# Altium schematic sheet ARDUINO_MINI_PRO.SchDoc
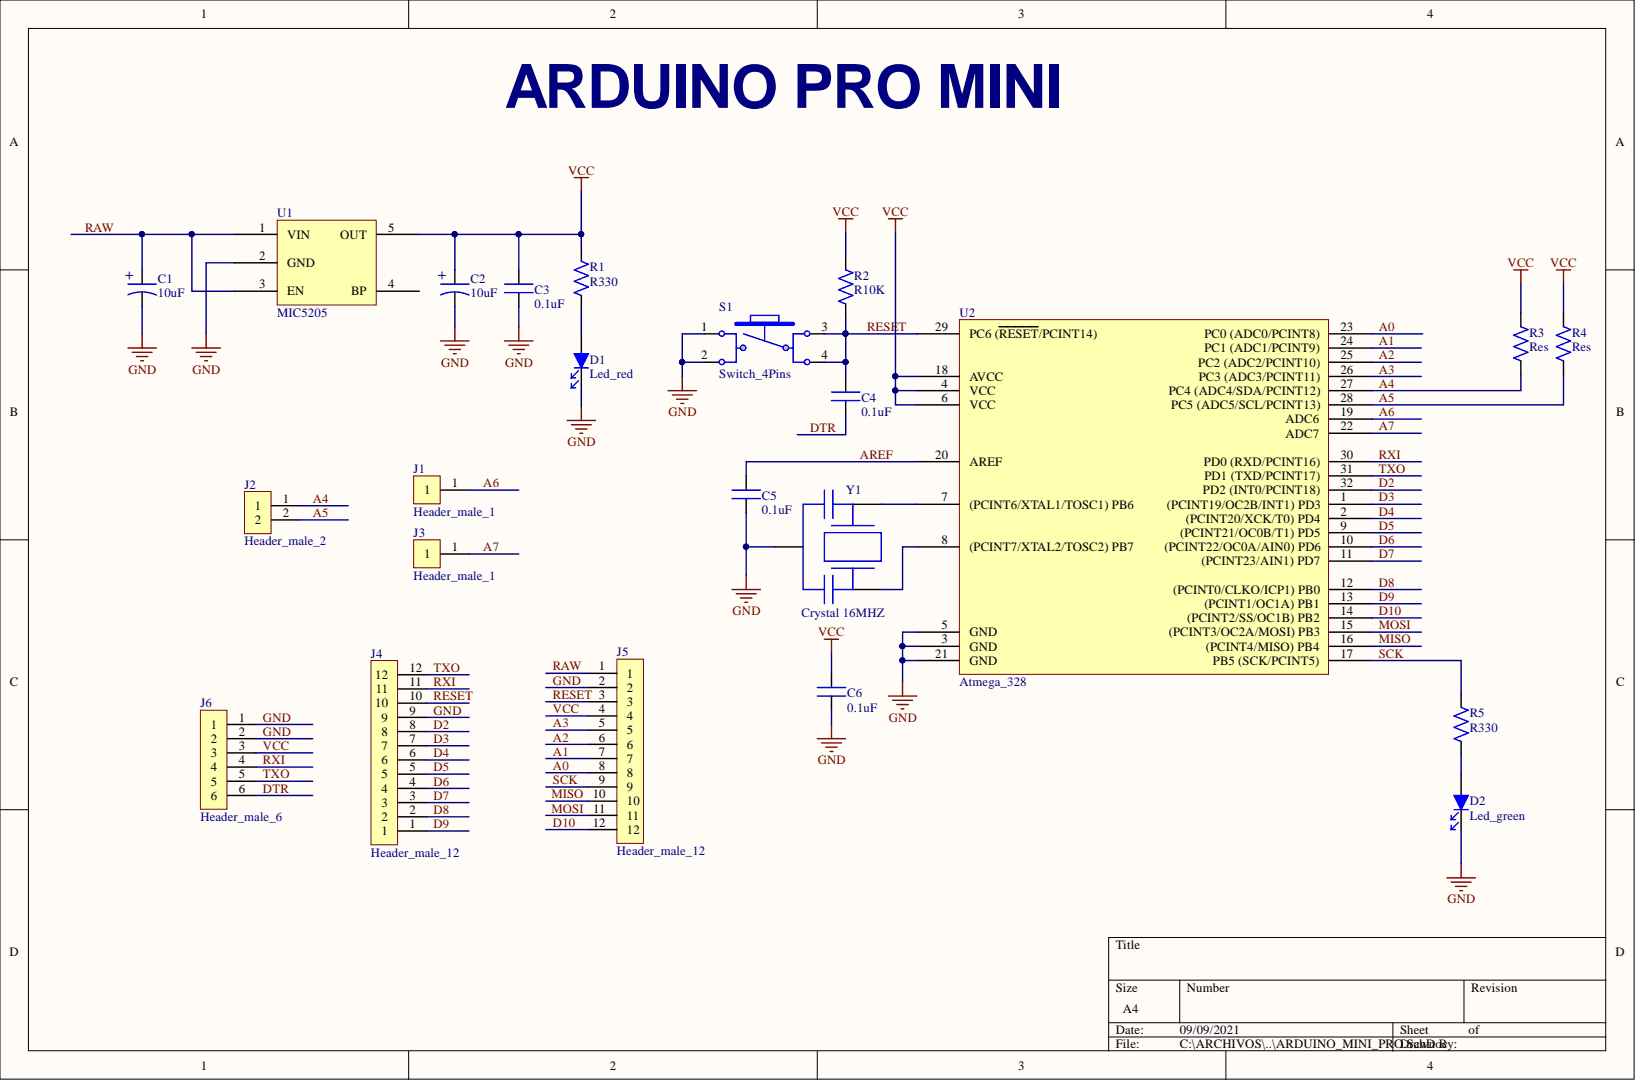
[diagram p0: ARDUINO_MINI_PRO.SchDoc]

## Parts
C1  10uF  [Cap3216]
C2  10uF  [Cap3216]
C3  0.1uF  [Cap_0402]
C4  0.1uF  [Cap_0402]
C5  0.1uF  [Cap_0402]
C6  0.1uF  [Cap_0402]
D1  Led_red  [Led_0603]
D2  Led_green  [Led_0603]
J1  Header_male_1  [Header_male_1]
J2  Header_male_2  [Header_male_2]
J3  Header_male_1  [Header_male_1]
J4  Header_male_12  [Header_male_12]
J5  Header_male_12  [Header_male_12]
J6  Header_male_6  [Header_male_6]
R1  R330  [Res_0402]
R2  R10K  [Res_0402]
R3  Res  [Res_0402_without3dmodel]
R4  Res  [Res_0402_without3dmodel]
R5  R330  [Res_0402]
S1  Switch_4Pins  [SWITCH_SMD_4_PINS]
U1  MIC5205  [SOT23_5]
U2  Atmega_328  [tqfp32_8]
Y1  Crystal 16MHZ  [CSTCE16M0V53-R0]

## Nets
A0: J5.8 U2.23(PC0_(ADC0/PCINT8))
A1: J5.7 U2.24(PC1_(ADC1/PCINT9))
A2: J5.6 U2.25(PC2_(ADC2/PCINT10))
A3: J5.5 U2.26(PC3_(ADC3/PCINT11))
A4: J2.1 R3.1 U2.27(PC4_(ADC4/SDA/PCINT12))
A5: J2.2 R4.1 U2.28(PC5_(ADC5/SCL/PCINT13))
A6: J1.1 U2.19(ADC6)
A7: J3.1 U2.22(ADC7)
AREF: C5.1 U2.20(AREF)
D2: J4.8 U2.32(PD2_(INT0/PCINT18))
D3: J4.7 U2.1((PCINT19/OC2B/INT1)_PD3)
D4: J4.6 U2.2((PCINT20/XCK/T0)_PD4)
D5: J4.5 U2.9((PCINT21/OC0B/T1)_PD5)
D6: J4.4 U2.10((PCINT22/OC0A/AIN0)_PD6)
D7: J4.3 U2.11((PCINT23/AIN1)_PD7)
D8: J4.2 U2.12((PCINT0/CLKO/ICP1)_PB0)
D9: J4.1 U2.13((PCINT1/OC1A)_PB1)
D10: J5.12 U2.14((PCINT2/SS/OC1B)_PB2)
DTR: C4.2 J6.6
GND: C1.2 C2.2 C3.2 C5.2 C6.2 D1.1 D2.1 J4.9 J5.2 J6.1 J6.2 S1.1 S1.2 U1.2(GND) U2.3(GND) U2.5(GND) U2.21(GND) Y1.2
MISO: J5.10 U2.16((PCINT4/MISO)_PB4)
MOSI: J5.11 U2.15((PCINT3/OC2A/MOSI)_PB3)
RAW: C1.1 J5.1 U1.1(VIN) U1.3(EN)
RESET: C4.1 J4.10 J5.3 R2.1 S1.3 S1.4 U2.29(PC6_(RESET/PCINT14))
RXI: J4.11 J6.4 U2.30(PD0_(RXD/PCINT16))
SCK: J5.9 R5.2 U2.17(PB5_(SCK/PCINT5))
TXO: J4.12 J6.5 U2.31(PD1_(TXD/PCINT17))
VCC: C2.1 C3.1 C6.1 J5.4 J6.3 R1.2 R2.2 R3.2 R4.2 U1.5(OUT) U2.4(VCC) U2.6(VCC) U2.18(AVCC)
NetD1_2: D1.2 R1.1
NetD2_2: D2.2 R5.1
NetU2_7: U2.7((PCINT6/XTAL1/TOSC1)_PB6) Y1.1
NetU2_8: U2.8((PCINT7/XTAL2/TOSC2)_PB7) Y1.3

Not connected: U1 4(BP)

## Library data of the parts
C1 C2 = Capacitor_10uF: CAP_POL1206_EIA3216; Component Kind: Standard; Library Name: ARDUINO_MINI_PRO.SchLib
C3-C6 = Capacitor_0.1uF: Capacitor_0.1uF_0402; Component Kind: Standard; Library Name: ARDUINO_MINI_PRO.SchLib
D1 = Led_red_0603: Component Kind: Standard; Description: Led_red_0603; Library Name: ARDUINO_MINI_PRO.SchLib
D2 = Led_green_0603: Component Kind: Standard; Description: Led_green_0603; Library Name: ARDUINO_MINI_PRO.SchLib
R1 R5 = Resistor_0402_R330: Component Kind: Standard; Description: Resistor_0402_R330; Library Name: ARDUINO_MINI_PRO.SchLib
R2 = Resistor_0402: Resistor_0402_R10K; Component Kind: Standard; Library Name: ARDUINO_MINI_PRO.SchLib
R3 R4 = Resistor: Resistor_0402; Component Kind: Standard; Library Name: ARDUINO_MINI_PRO.SchLib
S1 = Switch SMD 4 Pins: Switch_SMD_ 4Pins_5x5x1.5; Component Kind: Standard; Library Name: ARDUINO_MINI_PRO.SchLib
U1 = MIC5205: MIC5205_SOT23_5; Component Kind: Standard; Library Name: ARDUINO_MINI_PRO.SchLib
U2 = Atmega 328: Atmega 328_TQFP32_08; Component Kind: Standard; Library Name: ARDUINO_MINI_PRO.SchLib
Y1 = CSTCE16M0V53-R0: CSTCE16M0V53-R0-8/16/20MHz; Component Kind: Standard; Library Name: ARDUINO_MINI_PRO.SchLib
J1 J3 = Header_male_1: Component Kind: Standard; Description: Header_male_1; Library Name: ARDUINO_MINI_PRO.SchLib
J2 = Header_male_2: Component Kind: Standard; Description: Header_male_2; Library Name: ARDUINO_MINI_PRO.SchLib
J4 J5 = Header_male_12: Component Kind: Standard; Description: Header_male_12; Library Name: ARDUINO_MINI_PRO.SchLib
J6 = Header_male_6: Component Kind: Standard; Description: Header_male_6; Library Name: ARDUINO_MINI_PRO.SchLib
